# Altium schematic sheet main.SchDoc(main)
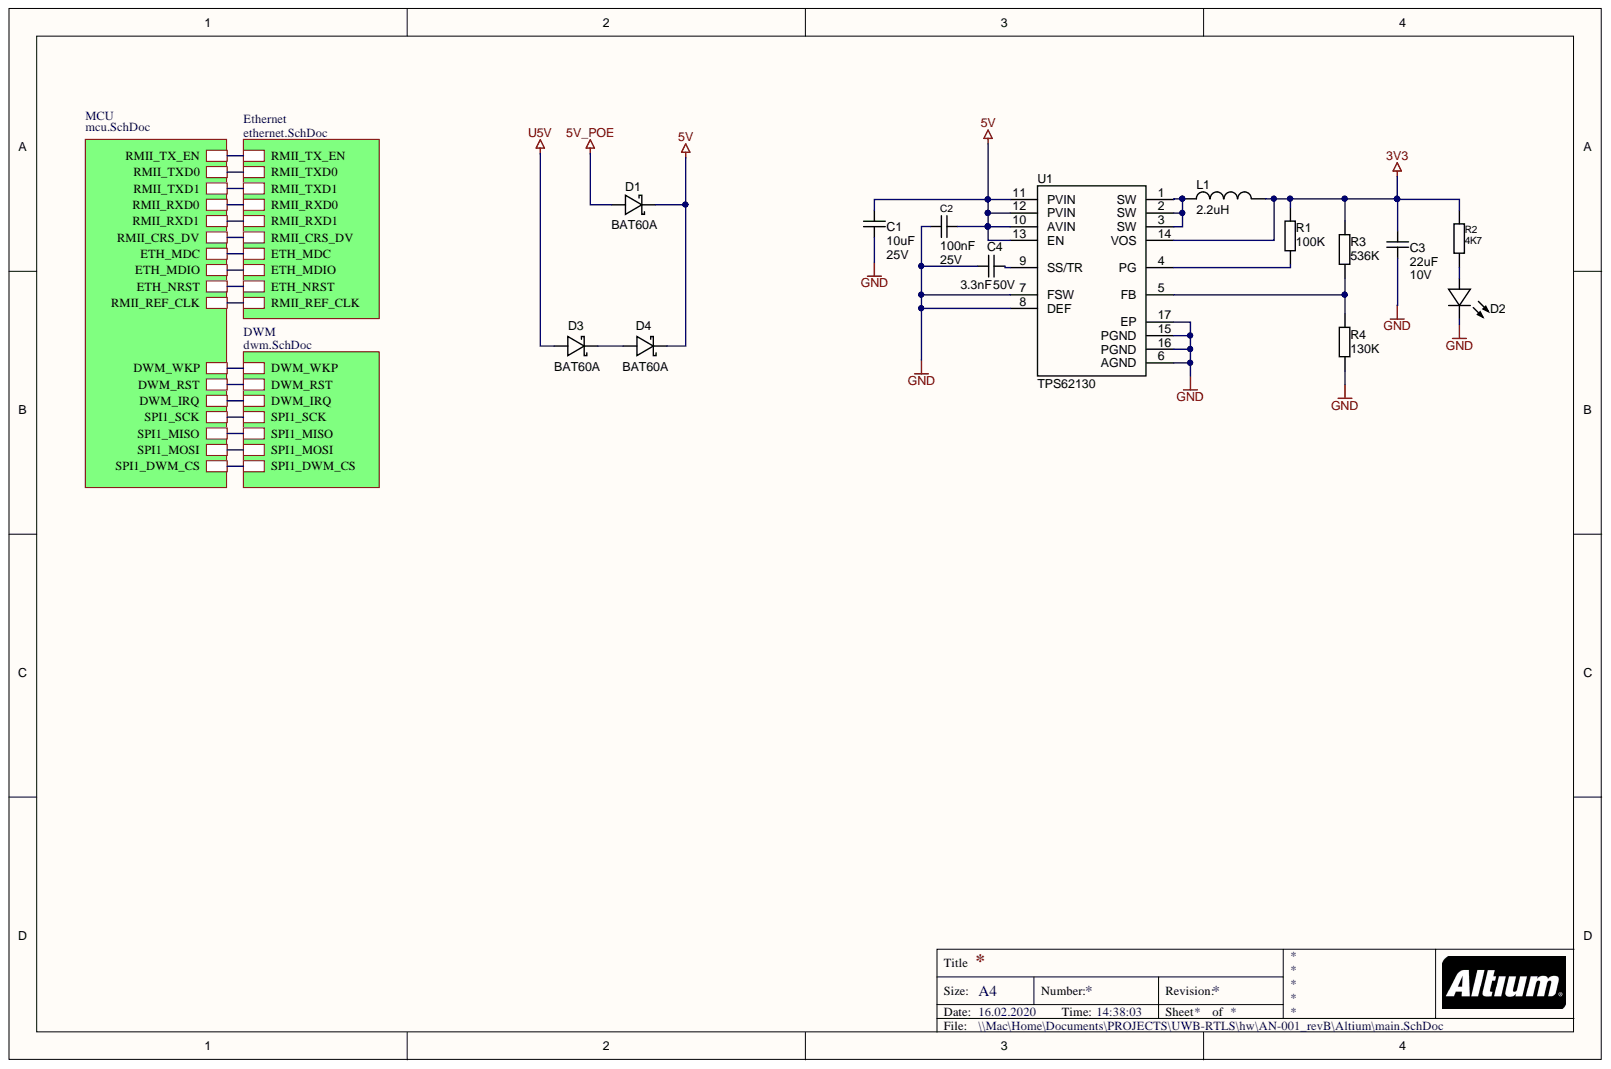
[diagram p0: main.SchDoc]

## Parts
C1  value 10uF  Voltage: 25V  [C0603]  (hidden comment: C0603)
C2  value 100nF  [C0402]  (hidden comment: C0402)
C3  value 22uF  Voltage Rating (DC): 10V  [C0603]  (hidden comment: C0603)
C4  C0603  [C0603]  (value not drawn)
D1  BAT60A  [SOD-323-2]
D2  LED0603  [LED0603]  (value not drawn)
D3  BAT60A  [SOD-323-2]
D4  BAT60A  [SOD-323-2]
L1  Inductance: 2.2uH  [SPM4012T-2R2M]  (hidden comment: SPM4012T-2R2M)
R1  value 100K  [R0402M]  (hidden comment: R0402)
R2  value 4K7  [R0402M]  (hidden comment: R0402)
R3  value 536K  [R0402M]  (hidden comment: R0402)
R4  value 130K  [R0402M]  (hidden comment: R0402)
U1  TPS62130  [QFN-16]

## Nets
3V3: C3.2 L1.2 R1.2 R2.1 R3.2 U1.14(VOS)
5V: C1.2 C2.1 D1.2 D4.2 U1.10(AVIN) U1.11(PVIN) U1.12(PVIN) U1.13(EN)
5V_POE: D1.1
GND: C1.1 C2.2 C3.1 C4.2 D2.1 R4.1 U1.6(AGND) U1.7(FSW) U1.8(DEF) U1.15(PGND) U1.16(PGND) U1.17(EP)
U5V: D3.1
NetC4_1: C4.1 U1.9(SS/TR)
NetD2_2: D2.2 R2.2
NetD3_2: D3.2 D4.1
NetL1_1: L1.1 U1.1(SW) U1.2(SW) U1.3(SW)
NetR1_1: R1.1 U1.4(PG)
NetR3_1: R3.1 R4.2 U1.5(FB)
DWM_IRQ:
DWM_RST:
DWM_WKP:
ETH_MDC:
ETH_MDIO:
ETH_NRST:
RMII_CRS_DV:
RMII_REF_CLK:
RMII_RXD0:
RMII_RXD1:
RMII_TX_EN:
RMII_TXD0:
RMII_TXD1:
SPI1_DWM_CS:
SPI1_MISO:
SPI1_MOSI:
SPI1_SCK:

## Library data of the parts
C1 = C0603: Manufacturer Part Number: GRM188R61E106KA73D; Manufacturer: Murata; Supplier Part Number 1: C344022; Supplier 1: LCSC; Stock 1: 412525; RoHS: No; Pricing 1: 5=0.08350, 50=0.06120, 150=0.05710, 500=0.05320, 2500=0.05050, 5000=0.05000, 5=0.07580, 50=0.05550, 150=0.05180, 500=0.04830, 2500=0.04580, 5000=0.04540, 5=0.40380, 50=0.29580, 150=0.27620, 500=0.25730, 2500=0.24400, 5000=0.24190, 5=0.38860, 50=0.28470, 150=0.26580, 500=0.24760, 2500=0.23480, 5000=0.23280, 5=0.05200, 50=0.03810, 150=0.03560, 500=0.03310, 2500=0.03140, 5000=0.03110, 5=0.04470, 50=0.03280, 150=0.03060, 500=0.02850, 2500=0.02700, 5000=0.02680, 5=0.45110, 50=0.33050, 150=0.30860, 500=0.28740, 2500=0.27250, 5000=0.27020, 5=6.28170, 50=4.60220, 150=4.29680, 500=4.00240, 2500=3.79520, 5000=3.76250, 5=0.23810, 50=0.17450, 150=0.16290, 500=0.15170, 2500=0.14390, 5000=0.14260, 5=3.65760, 50=2.67970, 150=2.50190, 500=2.33050, 2500=2.20980, 5000=2.19080, 5=0.55590, 50=0.40720, 150=0.38020, 500=0.35420, 2500=0.33580, 5000=0.33290, 5=0.07820, 50=0.05730, 150=0.05350, 500=0.04980, 2500=0.04730, 5000=0.04690, 5=0.05760, 50=0.04220, 150=0.03940, 500=0.03670, 2500=0.03480, 5000=0.03450 (AUD); Library Name: DZ_LIB.SchLib; ComponentLink1URL: https://search.murata.co.jp/Ceramy/image/img/A01X/G101/ENG/GRM188R61E106KA73-01.pdf?src-supplier=Digi-Key; ComponentLink1Description: https://search.murata.co.jp/Ceramy/image/img/A01X/G101/ENG/GRM188R61E106KA73-01.pdf?src-supplier=Digi-Key; Component Kind: Standard; Case/Package: 0603
C2 = C0402: Manufacturer Part Number: GCM155R71E104KE02D; Manufacturer: Murata; Voltage Rating: 25V; Tolerance: 10%; Voltage: 25V; Supplier Part Number 1: C126527; Supplier 1: LCSC; Stock 1: 0; RoHS: No; Ratings: AEC-Q200; Packaging: Cut Tape; Mount: Surface Mount; Min Operating Temperature: -55°C; Max Operating Temperature: 125°C; Library Name: DZ_LIB.SchLib; ComponentLink1URL: http://search.murata.co.jp/Ceramy/image/img/A01X/G101/ENG/GCM155R71E104KE02-01.pdf?src-supplier=Digi-Key; ComponentLink1Description: http://search.murata.co.jp/Ceramy/image/img/A01X/G101/ENG/GCM155R71E104KE02-01.pdf?src-supplier=Digi-Key; Component Kind: Standard; Case Code (Metric): 1005; Case Code (Imperial): 0402; Case/Package: 0402; Capacitance: 100nF
C3 = C0603: Manufacturer Part Number: GRM188R61A226ME15D; Manufacturer: Murata; Tolerance: 20%; Material: Ceramic; Dielectric: X5R; Width: 0.8mm; Weight: 0.000222oz; Voltage Rating: 10V; Voltage: 10V; Thickness: 0.039inch; Termination: SMD/SMT; Supplier Part Number 1: C84419; Supplier 1: LCSC; Stock 1: 271060; RoHS: Yes; REACH SVHC: No SVHC; Pricing 1: 20=0,04880, 200=0,03790, 600=0,03590, 2000=0,03380, 10000=0,03300, 20000=0,03250, 20=0,04430, 200=0,03440, 600=0,03250, 2000=0,03070, 10000=0,02990, 20000=0,02950, 20=0,23440, 200=0,18190, 600=0,17210, 2000=0,16230, 10000=0,15810, 20000=0,15600, 20=0,22720, 200=0,17630, 600=0,16680, 2000=0,15730, 10000=0,15330, 20000=0,15120, 20=0,03040, 200=0,02360, 600=0,02230, 2000=0,02110, 10000=0,02050, 20000=0,02020, 20=0,02620, 200=0,02040, 600=0,01930, 2000=0,01820, 10000=0,01770, 20000=0,01750, 20=0,26220, 200=0,20350, 600=0,19260, 2000=0,18160, 10000=0,17690, 20000=0,17460, 20=3,66000, 200=2,84060, 600=2,68760, 2000=2,53470, 10000=2,46910, 20000=2,43630, 20=0,13850, 200=0,10750, 600=0,10170, 2000=0,09590, 10000=0,09340, 20000=0,09220, 20=2,13660, 200=1,65830, 600=1,56900, 2000=1,47970, 10000=1,44140, 20000=1,42230, 20=0,32500, 200=0,25220, 600=0,23860, 2000=0,22510, 10000=0,21920, 20000=0,21630, 20=0,04550, 200=0,03530, 600=0,03340, 2000=0,03150, 10000=0,03070, 20000=0,03030, 20=0,03350, 200=0,02600, 600=0,02460, 2000=0,02320, 10000=0,02260, 20000=0,02230 (AUD); Packaging: Tape and Reel; Package Quantity: 4000; Mount: Surface Mount; Min Operating Temperature: -55°C; Max Operating Temperature: 85°C; Library Name: DZ_LIB.SchLib; Length: 1.6mm; Lead Free: Lead Free; Height: 0.8mm; Depth: 0.8mm; ComponentLink1URL: https://datasheet.ciiva.com/46444/1769893-46444975.pdf?src-supplier=Element14; ComponentLink1Description: https://datasheet.ciiva.com/46444/1769893-46444975.pdf?src-supplier=Element14; Component Kind: Standard; Case Code (Metric): 1608; Case Code (Imperial): 0603; Case/Package: 0603; Capacitance: 22uF
C4 = C0603: Manufacturer Part Number: GRM155R71H332KA01D; Manufacturer: Murata; Voltage Rating (DC): 50V; Value: 3.3nF; Tolerance: 10%; Material: Ceramic; Insulation Resistance: 10GR; Dissipation Factor: 0.025%; Dielectric: X7R; Width: 0.5mm; Voltage Rating: 50V; Voltage: 50V; Thickness: 0.022inch; Termination: SMD/SMT; Supplier Part Number 1: C85963; Supplier 1: LCSC; Stock 1: 111800; RoHS: Yes; REACH SVHC: No SVHC; Radiation Hardening: No; Pricing 1: 100=0.00320, 1000=0.00250, 3000=0.00230, 10000=0.00230, 50000=0.00220, 100000=0.00220, 100=0.00290, 1000=0.00220, 3000=0.00210, 10000=0.00210, 50000=0.00200, 100000=0.00200, 100=0.01540, 1000=0.01190, 3000=0.01120, 10000=0.01120, 50000=0.01050, 100000=0.01050, 100=0.01480, 1000=0.01150, 3000=0.01080, 10000=0.01080, 50000=0.01010, 100000=0.01010, 100=0.00200, 1000=0.00150, 3000=0.00140, 10000=0.00140, 50000=0.00140, 100000=0.00140, 100=0.00170, 1000=0.00130, 3000=0.00120, 10000=0.00120, 50000=0.00120, 100000=0.00120, 100=0.01720, 1000=0.01330, 3000=0.01250, 10000=0.01250, 50000=0.01170, 100000=0.01170, 100=0.23990, 1000=0.18540, 3000=0.17450, 10000=0.17450, 50000=0.16360, 100000=0.16360, 100=0.00910, 1000=0.00700, 3000=0.00660, 10000=0.00660, 50000=0.00620, 100000=0.00620, 100=0.13970, 1000=0.10800, 3000=0.10160, 10000=0.10160, 50000=0.09530, 100000=0.09530, 100=0.02120, 1000=0.01640, 3000=0.01540, 10000=0.01540, 50000=0.01450, 100000=0.01450, 100=0.00300, 1000=0.00230, 3000=0.00220, 10000=0.00220, 50000=0.00200, 100000=0.00200, 100=0.00220, 1000=0.00170, 3000=0.00160, 10000=0.00160, 50000=0.00150, 100000=0.00150 (AUD); Packaging: Tape and Reel; Package Quantity: 1; Mount: Surface Mount; Min Operating Temperature: -55°C; Max Operating Temperature: 125°C; Library Name: DZ_LIB.SchLib; Length: 1mm; Lead Free: Lead Free; Height: 0.5mm; Depth: 0.5mm; ComponentLink10URL: https://datasheet.ciiva.com/48671/product-48671326.pdf?src-supplier=Avnet; ComponentLink10Description: https://datasheet.ciiva.com/48671/product-48671326.pdf?src-supplier=Avnet; ComponentLink9URL: https://datasheet.ciiva.com/14116/grmseries-highdielectrictype-eng-14116909.pdf?src-supplier=Distrelec; ComponentLink9Description: https://datasheet.ciiva.com/14116/grmseries-highdielectrictype-eng-14116909.pdf?src-supplier=Distrelec; ComponentLink8URL: https://datasheet.ciiva.com/16199/product-16199259.pdf?src-supplier=Future+Electronics; ComponentLink8Description: https://datasheet.ciiva.com/16199/product-16199259.pdf?src-supplier=Future+Electronics; ComponentLink7URL: https://datasheet.ciiva.com/2989/product-2989413.pdf?src-supplier=Avnet; ComponentLink7Description: https://datasheet.ciiva.com/2989/product-2989413.pdf?src-supplier=Avnet; ComponentLink6URL: https://datasheet.ciiva.com/29199/454326-da-01-en-vs-kondensator-0402-29199651.pdf?src-supplier=Conrad; ComponentLink6Description: https://datasheet.ciiva.com/29199/454326-da-01-en-vs-kondensator-0402-29199651.pdf?src-supplier=Conrad; ComponentLink5URL: https://datasheet.ciiva.com/18184/getdatasheetpartid-149678-18184747.pdf?src-supplier=Verical; ComponentLink5Description: https://datasheet.ciiva.com/18184/getdatasheetpartid-149678-18184747.pdf?src-supplier=Verical; ComponentLink4URL: https://datasheet.ciiva.com/11789/0900766b80ef921d-11789161.pdf?src-supplier=RSComponents; ComponentLink4Description: https://datasheet.ciiva.com/11789/0900766b80ef921d-11789161.pdf?src-supplier=RSComponents; ComponentLink3URL: https://datasheet.ciiva.com/129/grm155r71h332ka01-01-129767.pdf?src-supplier=Digi-Key; ComponentLink3Description: https://datasheet.ciiva.com/129/grm155r71h332ka01-01-129767.pdf?src-supplier=Digi-Key; ComponentLink2URL: https://datasheet.ciiva.com/129/grm155r71h332ka01-01-129766.pdf?src-supplier=Digi-Key; ComponentLink2Description: https://datasheet.ciiva.com/129/grm155r71h332ka01-01-129766.pdf?src-supplier=Digi-Key; ComponentLink1URL: https://datasheet.ciiva.com/5422/product-5422403.pdf?src-supplier=Mouser; ComponentLink1Description: https://datasheet.ciiva.com/5422/product-5422403.pdf?src-supplier=Mouser; Component Kind: Standard; Case Code (Metric): 1005; Case Code (Imperial): 0402; Case/Package: 0402; Capacitance: 3.3nF
D1 D3 D4 = BAT60J: DIODE SCHOTTKY 10V 3A SOD323; Manufacturer Part Number: BAT60JFILM; Manufacturer: STMicroelectronics; Polarity: Standard; Peak Reverse Current: 7.5uA; Peak Non-Repetitive Surge Current: 5A; Forward Voltage: 580mV; Element Configuration: Single; Width: 1.45mm; Weight: 0.01lb; Voltage Rating (DC): 10V; Supplier Part Number 1: C133103; Supplier 1: LCSC; Stock 1: 9670; RoHS: Yes; REACH SVHC: No SVHC; Radiation Hardening: No; Pricing 1: 10=0.07540, 100=0.05580, 300=0.05220, 1000=0.04860, 5000=0.04700, 10000=0.04630, 10=0.06840, 100=0.05070, 300=0.04740, 1000=0.04410, 5000=0.04260, 10000=0.04200, 10=0.36450, 100=0.26990, 300=0.25240, 1000=0.23480, 5000=0.22710, 10000=0.22360, 10=0.35080, 100=0.25970, 300=0.24290, 1000=0.22600, 5000=0.21860, 10000=0.21520, 10=0.04690, 100=0.03480, 300=0.03250, 1000=0.03020, 5000=0.02930, 10000=0.02880, 10=0.04040, 100=0.02990, 300=0.02800, 1000=0.02600, 5000=0.02520, 10000=0.02480, 10=0.40720, 100=0.30150, 300=0.28190, 1000=0.26230, 5000=0.25370, 10000=0.24980, 10=5.67100, 100=4.19870, 300=3.92610, 1000=3.65340, 5000=3.53340, 10000=3.47890, 10=0.21500, 100=0.15920, 300=0.14880, 1000=0.13850, 5000=0.13400, 10000=0.13190, 10=3.30200, 100=2.44480, 300=2.28600, 1000=2.12730, 5000=2.05740, 10000=2.02570, 10=0.50180, 100=0.37150, 300=0.34740, 1000=0.32330, 5000=0.31270, 10000=0.30780, 10=0.07060, 100=0.05230, 300=0.04890, 1000=0.04550, 5000=0.04400, 10000=0.04330, 10=0.05200, 100=0.03850, 300=0.03600, 1000=0.03350, 5000=0.03240, 10000=0.03190 (AUD); Packaging: Tape and Reel; Package Quantity: 1; Output Current: 3A; Number of Pins: 2; Mount: Surface Mount; Min Operating Temperature: -65°C; Max Surge Current: 5A; Max Reverse Voltage (DC): 10V; Max Reverse Leakage Current: 7.5uA; Max Repetitive Reverse Voltage (Vrrm): 10V; Max Operating Temperature: 150°C; Max Forward Surge Current (Ifsm): 5A; Library Name: DZ_LIB.SchLib; Length: 1.8mm; Lead Free: Lead Free; Height: 1.07mm; Forward Current: 3A; Current Rating: 3A; Contact Plating: Tin,Matte; ComponentLink8URL: https://datasheet.ciiva.com/15144/getdatasheetpartid-91415-15144683.pdf?src-supplier=Verical; ComponentLink8Description: https://datasheet.ciiva.com/15144/getdatasheetpartid-91415-15144683.pdf?src-supplier=Verical; ComponentLink7URL: https://datasheet.ciiva.com/915/cd00001944-915609.pdf?src-supplier=Digi-Key; ComponentLink7Description: https://datasheet.ciiva.com/915/cd00001944-915609.pdf?src-supplier=Digi-Key; ComponentLink6URL: https://datasheet.ciiva.com/915/cd00001944-915608.pdf?src-supplier=Digi-Key; ComponentLink6Description: https://datasheet.ciiva.com/915/cd00001944-915608.pdf?src-supplier=Digi-Key; ComponentLink5URL: https://datasheet.ciiva.com/915/cd00001944-915607.pdf?src-supplier=Digi-Key; ComponentLink5Description: https://datasheet.ciiva.com/915/cd00001944-915607.pdf?src-supplier=Digi-Key; ComponentLink4URL: https://datasheet.ciiva.com/17078/bat60jfilm-17078717.pdf?src-supplier=Allied+Electronics; ComponentLink4Description: https://datasheet.ciiva.com/17078/bat60jfilm-17078717.pdf?src-supplier=Allied+Electronics; ComponentLink3URL: https://datasheet.ciiva.com/4714/cd00001944-96586-4714681.pdf?src-supplier=Mouser; ComponentLink3Description: https://datasheet.ciiva.com/4714/cd00001944-96586-4714681.pdf?src-supplier=Mouser; ComponentLink2URL: https://datasheet.ciiva.com/1200/1689728-1200741.pdf?src-supplier=Farnell; ComponentLink2Description: https://datasheet.ciiva.com/1200/1689728-1200741.pdf?src-supplier=Farnell; ComponentLink1URL: https://datasheet.ciiva.com/23916/cd00001944-23916545.pdf?src-supplier=Newark; ComponentLink1Description: https://datasheet.ciiva.com/23916/cd00001944-23916545.pdf?src-supplier=Newark; Component Kind: Standard; Average Rectified Current: 3A
D2 = LED0603: LED SMD 0603; Manufacturer Part Number: FC-DA1608HGK-572C; Manufacturer: Foshan NationStar Optoelectronics; Color: Green; Supplier Part Number 1: C84264; Supplier 1: LCSC; Library Name: DZ_LIB.SchLib; Component Kind: Standard
L1 = SPM4012T-2R2M: FIXED IND 2.2UH 2.5A 31 MOHM SMD; Manufacturer: TDK; Tolerance: 20%; Test Frequency: 100kHz; Shielding: Shielded; Saturation Current: 3.3A; RMS Current (Irms): 2.9A; DC Resistance (DCR): 95mR; Core Material: Metal,Ferrite; Width: 0.161inch; Supplier Part Number 1: C114774; Supplier 1: LCSC; Stock 1: 1206; RoHS: Yes; REACH SVHC: No SVHC; Pricing 1: 1=0.39250, 10=0.29170, 30=0.27410, 100=0.25660, 500=0.24780, 1000=0.24350, 1=0.35690, 10=0.26520, 30=0.24920, 100=0.23330, 500=0.22530, 1000=0.22130, 1=1.90850, 10=1.41800, 30=1.33290, 100=1.24770, 500=1.20480, 1000=1.18370, 1=1.81470, 10=1.34830, 30=1.26740, 100=1.18640, 500=1.14560, 1000=1.12550, 1=0.24280, 10=0.18040, 30=0.16960, 100=0.15880, 500=0.15330, 1000=0.15060, 1=0.20960, 10=0.15580, 30=0.14640, 100=0.13710, 500=0.13230, 1000=0.13000, 1=2.12520, 10=1.57900, 30=1.48420, 100=1.38940, 500=1.34160, 1000=1.31810, 1=29.33980, 10=21.79930, 30=20.49020, 100=19.18120, 500=18.52130, 1000=18.19670, 1=1.12970, 10=0.83930, 30=0.78890, 100=0.73850, 500=0.71310, 1000=0.70060, 1=17.23180, 10=12.80310, 30=12.03430, 100=11.26550, 500=10.87790, 1000=10.68730, 1=2.59370, 10=1.92710, 30=1.81140, 100=1.69570, 500=1.63730, 1000=1.60860, 1=0.36820, 10=0.27360, 30=0.25720, 100=0.24070, 500=0.23250, 1000=0.22840, 1=0.27120, 10=0.20150, 30=0.18940, 100=0.17730, 500=0.17120, 1000=0.16820 (AUD); Packaging: Cut Tape; Package Quantity: 1000; Mount: Surface Mount; Min Operating Temperature: -40°C; Max Operating Temperature: 125°C; Max DC Current: 3.3A; Manufacturer Part Number: SPM4012T-2R2M; Library Name: DZ_LIB.SchLib; Length: 0.173inch; Lead Free: Lead Free; Inductance Tolerance: 20%; Height - Seated (Max): 0.047inch; Height: 1.2mm; Depth: 4.1mm; Current Rating: 2.9A; Composition: Wirewound; ComponentLink3URL: https://datasheet.ciiva.com/46413/1805093-46413611.pdf?src-supplier=Element14; ComponentLink3Description: https://datasheet.ciiva.com/46413/1805093-46413611.pdf?src-supplier=Element14; ComponentLink2URL: https://datasheet.ciiva.com/46413/1805093-46413610.pdf?src-supplier=Element14; ComponentLink2Description: https://datasheet.ciiva.com/46413/1805093-46413610.pdf?src-supplier=Element14; ComponentLink1URL: https://datasheet.ciiva.com/29696/inductor-commercial-power-spm-en-29696448.pdf?src-supplier=Digi-Key; ComponentLink1Description: https://datasheet.ciiva.com/29696/inductor-commercial-power-spm-en-29696448.pdf?src-supplier=Digi-Key; Component Kind: Standard; Case/Package: Nonstandard
R1 = R0402: Manufacturer Part Number: RC0402FR-07100KL; Manufacturer: YAGEO; Supplier Part Number 1: C60491; Supplier 1: LCSC; Library Name: DZ_LIB.SchLib; Component Kind: Standard
R2 = R0402: Manufacturer Part Number: RC0402FR-074K7L; Manufacturer: YAGEO; Supplier Part Number 1: C105871; Supplier 1: LCSC; Library Name: DZ_LIB.SchLib; Component Kind: Standard
R3 = R0402: Manufacturer Part Number: RC0402FR-07536KL; Manufacturer: YAGEO; Supplier Part Number 1: C327337; Supplier 1: LCSC; Library Name: DZ_LIB.SchLib; Component Kind: Standard
R4 = R0402: Manufacturer Part Number: RC0402FR-07130KL; Manufacturer: YAGEO; Supplier Part Number 1: C93946; Supplier 1: LCSC; Library Name: DZ_LIB.SchLib; Component Kind: Standard
U1 = TPS62130RGTR: IC Buck 2A TI Fix 5V; Manufacturer: Texas Instruments; Synchronous Rectifier: Yes; Switching Frequency: 2.5MHz; Quiescent Current: 17uA; Output Voltage: 6V; Output Type: Adjustable; Output Current: 3A; Output Configuration: Positive; Operating Supply Voltage: 17V; Number of Outputs: 1; Min Output Voltage: 900mV; Min Input Voltage: 3V; Supplier Part Number 1: C43590; Supplier 1: LCSC; Stock 1: 8738; RoHS: Yes; REACH SVHC: No SVHC; Pricing 1: 1=0.94300, 10=0.69950, 30=0.65570, 100=0.60960, 500=0.59000, 1000=0.58110, 1=0.85730, 10=0.63600, 30=0.59610, 100=0.55430, 500=0.53640, 1000=0.52840, 1=4.58490, 10=3.40120, 30=3.18790, 100=2.96420, 500=2.86840, 1000=2.82550, 1=4.35950, 10=3.23400, 30=3.03120, 100=2.81850, 500=2.72750, 1000=2.68660, 1=0.58340, 10=0.43280, 30=0.40560, 100=0.37720, 500=0.36500, 1000=0.35950, 1=0.50360, 10=0.37360, 30=0.35020, 100=0.32560, 500=0.31510, 1000=0.31040, 1=5.10530, 10=3.78730, 30=3.54980, 100=3.30060, 500=3.19410, 1000=3.14630, 1=70.48260, 10=52.28580, 30=49.00780, 100=45.56750, 500=44.09620, 1000=43.43630, 1=2.71380, 10=2.01320, 30=1.88700, 100=1.75450, 500=1.69790, 1000=1.67240, 1=41.39570, 10=30.70840, 30=28.78320, 100=26.76270, 500=25.89850, 1000=25.51090, 1=6.23090, 10=4.62220, 30=4.33240, 100=4.02830, 500=3.89820, 1000=3.83990, 1=0.88460, 10=0.65620, 30=0.61510, 100=0.57190, 500=0.55340, 1000=0.54520, 1=0.65150, 10=0.48330, 30=0.45300, 100=0.42120, 500=0.40760, 1000=0.40150 (AUD); Packaging: Tape and Reel; Package Quantity: 3000; Number of Pins: 16; Min Operating Temperature: -40°C; Max Output Voltage: 6V; Max Output Current: 3A; Max Operating Temperature: 125°C; Max Input Voltage: 17V; Manufacturer Part Number: TPS62130RGTR; Library Name: DZ_LIB.SchLib; Lead Free: Lead Free; Contact Plating: Gold; ComponentLink3URL: https://datasheet.ciiva.com/41799/tps62130-41799070.pdf?src-supplier=Digi-Key; ComponentLink3Description: https://datasheet.ciiva.com/41799/tps62130-41799070.pdf?src-supplier=Digi-Key; ComponentLink2URL: https://datasheet.ciiva.com/14377/tps62130-14377867.pdf?src-supplier=Avnet; ComponentLink2Description: https://datasheet.ciiva.com/14377/tps62130-14377867.pdf?src-supplier=Avnet; ComponentLink1URL: https://datasheet.ciiva.com/4880/slvsag7-121391-4880626.pdf?src-supplier=Mouser; ComponentLink1Description: https://datasheet.ciiva.com/4880/slvsag7-121391-4880626.pdf?src-supplier=Mouser; Component Kind: Standard; Case/Package: QFN
